# Altium schematic sheet STB_ControlBoard.SchDoc
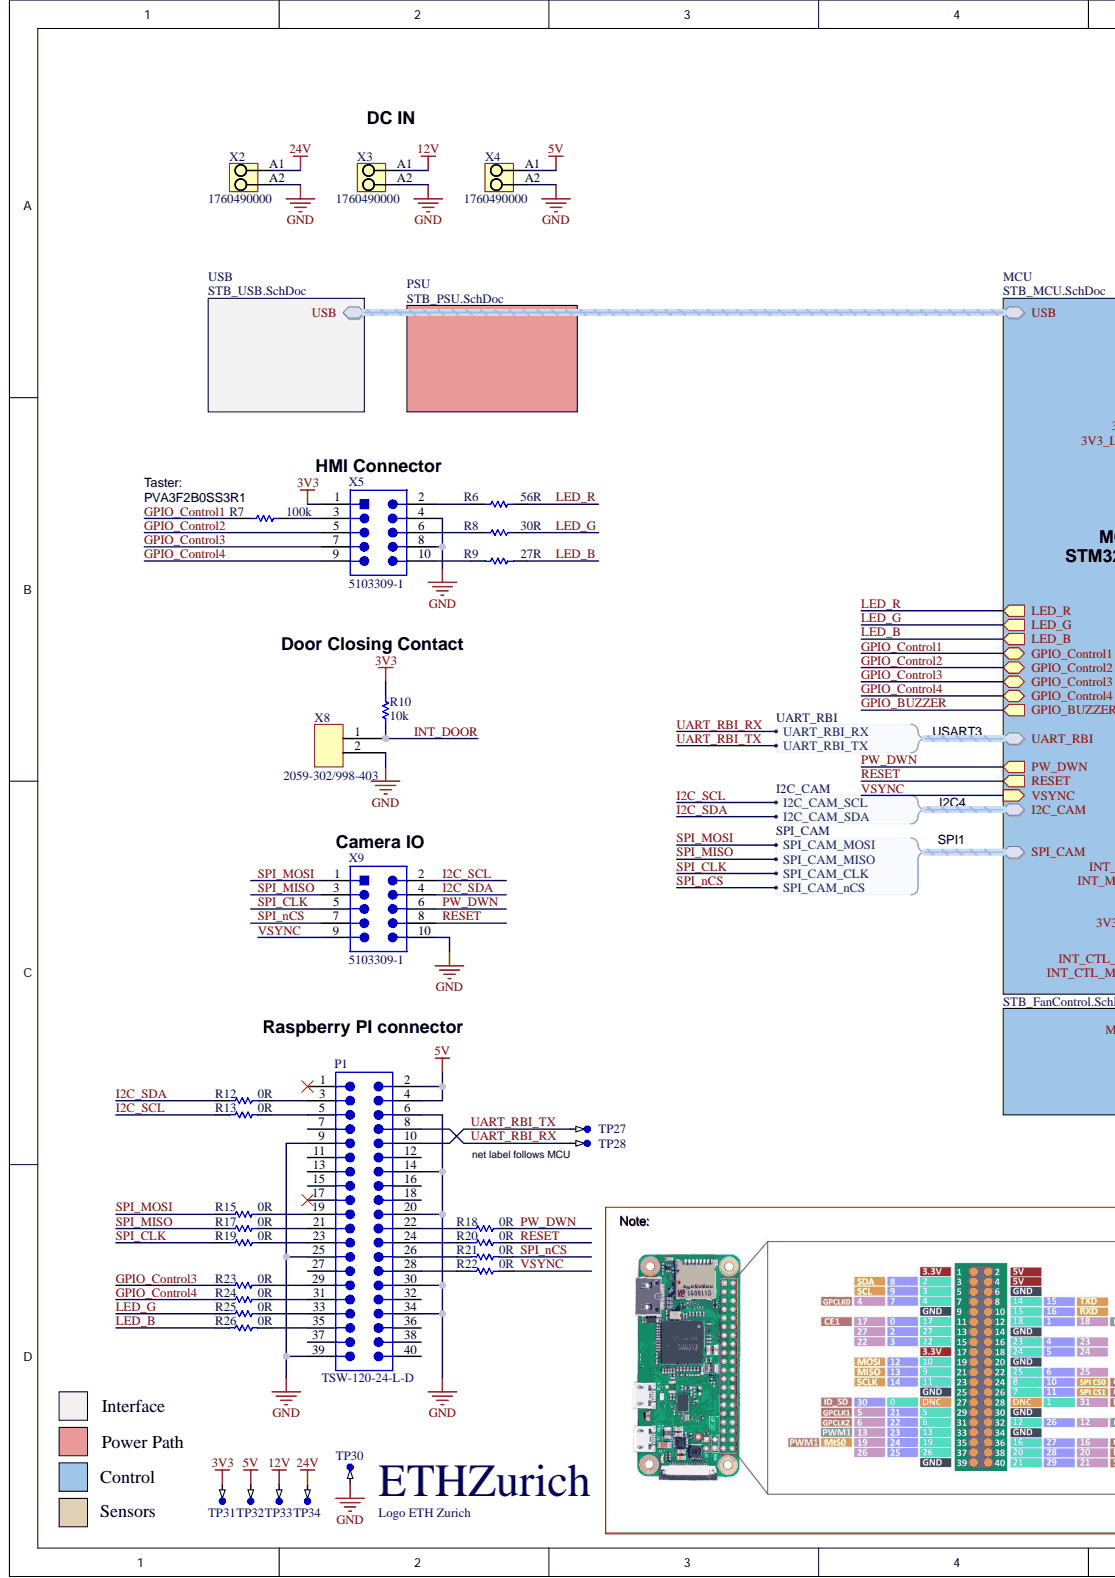
[diagram p0: STB_ControlBoard.SchDoc, left]
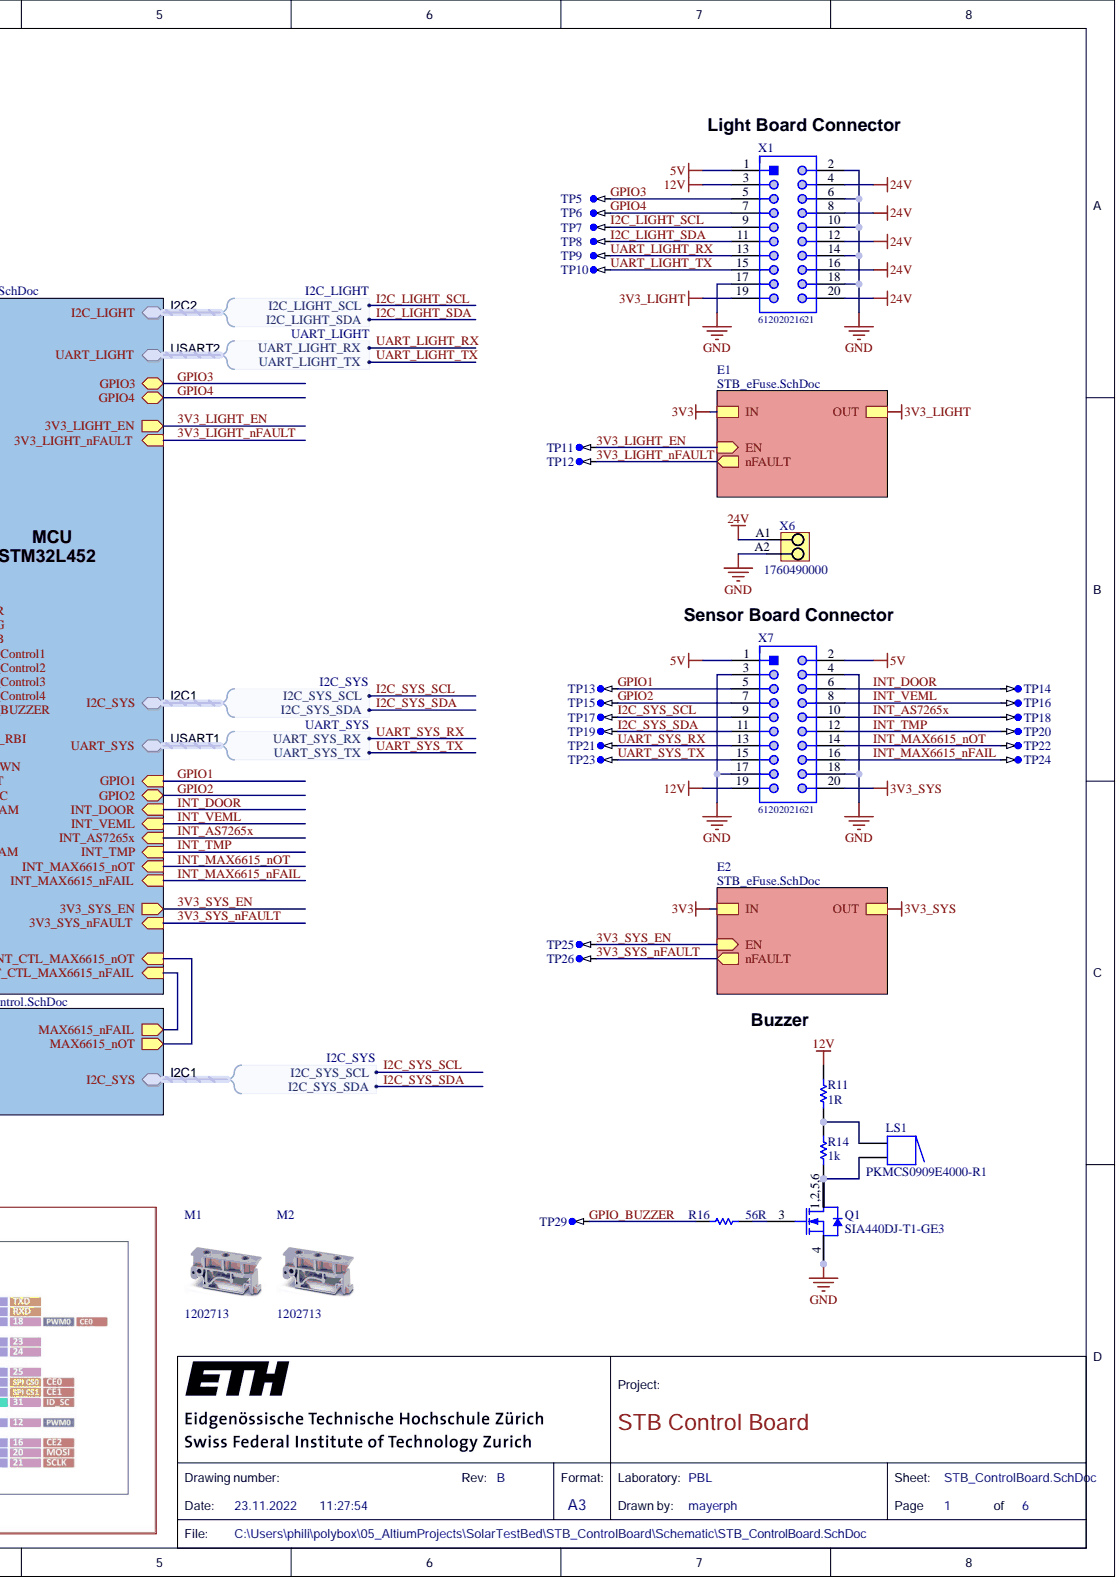
[diagram p1: STB_ControlBoard.SchDoc, right]

## Parts
A1  Logo ETH Zurich  [eth-zurich_3mm]  (no BOM)
LS1  PKMCS0909E4000-R1  [MURA- PKMCS0909E4000-2_V]
M1  1202713  [1202713]
M2  1202713  [1202713]
P1  TSW-120-24-L-D  [SMTC-TSW-120-24-X-D]
Q1  SIA440DJ-T1-GE3  [SC70-6L_65P210X80_HS-7L]
R6  56R  [RESC1609X50X30LL10T20]
R7  100k  [RESC1005X40X25LL05T10]
R8  30R  [RESC1609X50X30LL10T20]
R9  27R  [RESC1608X55X30LL15T15]
R10  10k  [RESC1005X40X25LL05T05]
R11  1R  [RESC3225X70X50NL20T20]
R12  0R  [RESC1005X40X25LL05T10]
R13  0R  [RESC1005X40X25LL05T10]
R14  1k  [RESC3216X70X50LL05T20]
R15  0R  [RESC1005X40X25LL05T10]
R16  56R  [RESC1609X50X30LL10T20]
R17  0R  [RESC1005X40X25LL05T10]
R18  0R  [RESC1005X40X25LL05T10]
R19  0R  [RESC1005X40X25LL05T10]
R20  0R  [RESC1005X40X25LL05T10]
R21  0R  [RESC1005X40X25LL05T10]
R22  0R  [RESC1005X40X25LL05T10]
R23  0R  [RESC1005X40X25LL05T10]
R24  0R  [RESC1005X40X25LL05T10]
R25  0R  [RESC1005X40X25LL05T10]
R26  0R  [RESC1005X40X25LL05T10]
TP5  TP_1mm  [TP-SM-C-100]  (value not drawn)  (no BOM)
TP6  TP_1mm  [TP-SM-C-100]  (value not drawn)  (no BOM)
TP7  TP_1mm  [TP-SM-C-100]  (value not drawn)  (no BOM)
TP8  TP_1mm  [TP-SM-C-100]  (value not drawn)  (no BOM)
TP9  TP_1mm  [TP-SM-C-100]  (value not drawn)  (no BOM)
TP10  TP_1mm  [TP-SM-C-100]  (value not drawn)  (no BOM)
TP11  TP_1mm  [TP-SM-C-100]  (value not drawn)  (no BOM)
TP12  TP_1mm  [TP-SM-C-100]  (value not drawn)  (no BOM)
TP13  TP_1mm  [TP-SM-C-100]  (value not drawn)  (no BOM)
TP14  TP_1mm  [TP-SM-C-100]  (value not drawn)  (no BOM)
TP15  TP_1mm  [TP-SM-C-100]  (value not drawn)  (no BOM)
TP16  TP_1mm  [TP-SM-C-100]  (value not drawn)  (no BOM)
TP17  TP_1mm  [TP-SM-C-100]  (value not drawn)  (no BOM)
TP18  TP_1mm  [TP-SM-C-100]  (value not drawn)  (no BOM)
TP19  TP_1mm  [TP-SM-C-100]  (value not drawn)  (no BOM)
TP20  TP_1mm  [TP-SM-C-100]  (value not drawn)  (no BOM)
TP21  TP_1mm  [TP-SM-C-100]  (value not drawn)  (no BOM)
TP22  TP_1mm  [TP-SM-C-100]  (value not drawn)  (no BOM)
TP23  TP_1mm  [TP-SM-C-100]  (value not drawn)  (no BOM)
TP24  TP_1mm  [TP-SM-C-100]  (value not drawn)  (no BOM)
TP25  TP_1mm  [TP-SM-C-100]  (value not drawn)  (no BOM)
TP26  TP_1mm  [TP-SM-C-100]  (value not drawn)  (no BOM)
TP27  TP_1mm  [TP-SM-C-100]  (value not drawn)  (no BOM)
TP28  TP_1mm  [TP-SM-C-100]  (value not drawn)  (no BOM)
TP29  TP_1mm  [TP-SM-C-100]  (value not drawn)  (no BOM)
TP30  TP_1mm  [TP-SM-C-100]  (value not drawn)  (no BOM)
TP31  TP_1mm  [TP-SM-C-100]  (value not drawn)  (no BOM)
TP32  TP_1mm  [TP-SM-C-100]  (value not drawn)  (no BOM)
TP33  TP_1mm  [TP-SM-C-100]  (value not drawn)  (no BOM)
TP34  TP_1mm  [TP-SM-C-100]  (value not drawn)  (no BOM)
X1  61202021621  [61202021621]
X2  1760490000  [PM_5_08_90_02]
X3  1760490000  [PM_5_08_90_02]
X4  1760490000  [PM_5_08_90_02]
X5  5103309-1  [TECO-5103309-1_V]
X6  1760490000  [PM_5_08_90_02]
X7  61202021621  [61202021621]
X8  2059-302/998-403  [2059-302/998-403]
X9  5103309-1  [TECO-5103309-1_V]

## Nets
3V3: R10.2 TP31.TP X5.1
3V3_LIGHT: X1.19
3V3_SYS: X7.20
5V: P1.2 P1.4 TP32.TP X1.1 X4.A1 X7.1 X7.2
12V: R11.2 TP33.TP X1.3 X3.A1 X7.19
24V: TP34.TP X1.4 X1.8 X1.12 X1.16 X1.20 X2.A1 X6.A1
GND: P1.6 P1.9 P1.14 P1.20 P1.25 P1.30 P1.34 P1.39 Q1.4 Q1.S TP30.TP X1.2 X1.6 X1.10 X1.14 X1.17 X1.18 X2.A2 X3.A2 X4.A2 X5.4 X5.8 X6.A2 X7.3 X7.4 X7.17 X7.18 X8.2 X9.10
3V3_LIGHT_EN: TP11.TP
3V3_LIGHT_nFAULT: TP12.TP
3V3_SYS_EN: TP25.TP
3V3_SYS_nFAULT: TP26.TP
GPIO1: TP13.TP X7.5
GPIO2: TP15.TP X7.7
GPIO3: TP5.TP X1.5
GPIO4: TP6.TP X1.7
GPIO_BUZZER: R16.2 TP29.TP
GPIO_Control1: R7.2
GPIO_Control2: X5.5
GPIO_Control3: R23.1 X5.7
GPIO_Control4: R24.1 X5.9
I2C_LIGHT_SCL: TP7.TP X1.9
I2C_LIGHT_SDA: TP8.TP X1.11
I2C_SCL: R13.1 X9.2
I2C_SDA: R12.1 X9.4
I2C_SYS_SCL: TP17.TP X7.9
I2C_SYS_SDA: TP19.TP X7.11
INT_AS7265x: TP18.TP X7.10
INT_DOOR: R10.1 TP14.TP X7.6 X8.1
INT_MAX6615_nFAIL: TP24.TP X7.16
INT_MAX6615_nOT: TP22.TP X7.14
INT_TMP: TP20.TP X7.12
INT_VEML: TP16.TP X7.8
LED_B: R9.1 R26.1
LED_G: R8.1 R25.1
LED_R: R6.1
PW_DWN: R18.2 X9.6
RESET: R20.2 X9.8
SPI_CLK: R19.1 X9.5
SPI_MISO: R17.1 X9.3
SPI_MOSI: R15.1 X9.1
SPI_nCS: R21.2 X9.7
UART_LIGHT_RX: TP9.TP X1.13
UART_LIGHT_TX: TP10.TP X1.15
UART_RBI_RX: P1.8 TP28.TP
UART_RBI_TX: P1.10 TP27.TP
UART_SYS_RX: TP21.TP X7.13
UART_SYS_TX: TP23.TP X7.15
VSYNC: R22.2 X9.9
NetLS1_1: LS1.1 R11.1 R14.2
NetLS1_2: LS1.2 Q1.1 Q1.2 Q1.5 Q1.6 Q1.TP R14.1
NetP1_1: P1.1
NetP1_3: P1.3 R12.2
NetP1_5: P1.5 R13.2
NetP1_7: P1.7
NetP1_11: P1.11
NetP1_12: P1.12
NetP1_13: P1.13
NetP1_15: P1.15
NetP1_16: P1.16
NetP1_17: P1.17
NetP1_18: P1.18
NetP1_19: P1.19 R15.2
NetP1_21: P1.21 R17.2
NetP1_22: P1.22 R18.1
NetP1_23: P1.23 R19.2
NetP1_24: P1.24 R20.1
NetP1_26: P1.26 R21.1
NetP1_27: P1.27
NetP1_28: P1.28 R22.1
NetP1_29: P1.29 R23.2
NetP1_31: P1.31 R24.2
NetP1_32: P1.32
NetP1_33: P1.33 R25.2
NetP1_35: P1.35 R26.2
NetP1_36: P1.36
NetP1_37: P1.37
NetP1_38: P1.38
NetP1_40: P1.40
NetQ1_3: Q1.3 R16.1
NetR6_2: R6.2 X5.2
NetR7_1: R7.1 X5.3
NetR8_2: R8.2 X5.6
NetR9_2: R9.2 X5.10
INT_CTL_MAX6615_nFAIL:
INT_CTL_MAX6615_nOT:
USB.USB_N:
USB.USB_P:

## Library data of the parts
A1 = CMP-00038-1: Library Name: ETHZ Vault
LS1 = CMP-2000-05252-1: Audio Indicators & Alerts Piezo Buzzer, 12.5 V, -40 to 85 degC, 2-Pin SMD, RoHS, Tape and Reel; Manufacturer: Murata; Brand: MurataElectronics; Frequency: 4kHz; Sound Pressure Level: 65dBA; Tone: Beep; Voltage Rating: 12.5VDC; Application: AlertBeep; Component Kind: Standard; Datasheet URL: https://datasheet.ciiva.com/24244/pkmcs0909e4000-r1-304946-24244386.pdf; Datasheet Version: Feb-13; Dimensions: 9mmLx9mmWx1.9mmH; Factory Pack Quantity: 2000; Height: 1.9mm; Height - in: 0.075in; Height - mm: 1.9mm; Length: 9mm; Length - in: 0.354in; Length - mm: 9mm; Library Name: Altium Content Vault; Manufacturer Part Number: PKMCS0909E4000-R1; Manufacturer URL: http://www.murata.com/; Mounting: SMD/SMT; Packaging: Reel; Product: Speakers; Product Category: AudioIndicators&Alerts; RoHS: True; Series: PK; Termination Style: GullwingLead; Tradename: PIEZORINGER; Type: Piezoelectric; Width: 9mm; Width - in: 0.354in; Width - mm: 9mm
M1 M2 = 1202713: EMI/EMD/EMC Protections; Manufacturer: Phoenix Contact; Category: Connectors; Component Kind: Standard; ComponentLink1Description: https://datasheet.ciiva.com:443/pdfs/VipMasterIC/IC/PCON/PCON-S-A0000016077/PCON-S-A0000017096-1.pdf?src-supplier=IHS+Markit; ComponentLink1URL: https://datasheet.ciiva.com:443/pdfs/VipMasterIC/IC/PCON/PCON-S-A0000016077/PCON-S-A0000017096-1.pdf?src-supplier=IHS+Markit; ComponentLink2Description: https://datasheet.ciiva.com/6712/1202713-6712528.pdf?src-supplier=Digi-Key; ComponentLink2URL: https://datasheet.ciiva.com/6712/1202713-6712528.pdf?src-supplier=Digi-Key; ComponentLink3Description: https://datasheet.ciiva.com/15656/1202713-15656099.pdf?src-supplier=Onlinecomponents.com; ComponentLink3URL: https://datasheet.ciiva.com/15656/1202713-15656099.pdf?src-supplier=Onlinecomponents.com; ComponentLink4Description: https://datasheet.ciiva.com/29271/715928-da-01-de-schienenadapter-1202713-10-st-29271415.pdf?src-supplier=Conrad; ComponentLink4URL: https://datasheet.ciiva.com/29271/715928-da-01-de-schienenadapter-1202713-10-st-29271415.pdf?src-supplier=Conrad; ComponentLink5Description: https://datasheet.ciiva.com/14616/70171402-14616683.pdf?src-supplier=Allied+Electronics; ComponentLink5URL: https://datasheet.ciiva.com/14616/70171402-14616683.pdf?src-supplier=Allied+Electronics; Manufacturer Part Number: 1202713; Pricing 1: 1=1.92000, 10=1.47000, 50=1.35240, 100=1.24950, 250=1.19072, 500=1.11720, 1000=1.05876, 2500=1.03951, 5000=1.02411 (USD); RoHS: Yes; Stock 1: 1956; Supplier 1: Digi-Key; Supplier Part Number 1: 277-2289-ND; Library Name: STB_Schlib.SchLib
P1 = CMP-1024-00454-1: 0.025" SQ Post Header, Through-hole, Vertical, -55 to 125 degC, 2.54 mm Pitch, 40-Pin, Male, RoHS; Manufacturer: Samtec; Pitch: 2.54 mm; Component Kind: Standard; ComponentLink1Description: Manufacturer URL; ComponentLink1URL: http://www.samtec.com; ComponentLink2Description: Datasheet; ComponentLink2URL: http://www.samtec.com/documents/webfiles/pdf/TSW_TH.PDF; ComponentLink3Description: Package Specification; ComponentLink3URL: http://www.samtec.com/documents/webfiles/cpdf/TSW-XXX-XX-XXX-X-XX-XXX-MKT.pdf; DatasheetVersion: F-214; Library Name: Altium Content Vault; Manufacturer Part Number: TSW-120-24-L-D; Mounting Technology: Through-hole; Orientation: Vertical; PackageDescription: .025[0.64] SQ. Post Terminal Strip Assembly; PackageReference: TSW-120-24-X-D; PackageVersion: DM, 8/1988; RoHS: True; Temperature Range: -55 to 125 degC
Q1 = SIA440DJ-T1-GE3: VISHAY   SIA440DJ-T1-GE3   MOSFET Transistor, N Channel, 12 A, 40 V, 0.021 ohm, 10 V; Manufacturer: Vishay Siliconix; Continuous Drain Current (ID): 12A; Drain to Source Breakdown Voltage: 40V; Drain to Source Resistance: 26mR; Element Configuration: Single; Fall Time: 5ns; Gate to Source Voltage (Vgs): 12V; Input Capacitance: 700pF; Max Power Dissipation: 19W; Number of Channels: 1; Rise Time: 32ns; Turn-Off Delay Time: 23ns; Turn-On Delay Time: 12ns; Category: MOSFETs; Component Kind: Standard; ComponentLink1Description: https://datasheet.ciiva.com/29746/sia440dj-29746688.pdf?src-supplier=Digi-Key; ComponentLink1URL: https://datasheet.ciiva.com/29746/sia440dj-29746688.pdf?src-supplier=Digi-Key; ComponentLink2Description: https://datasheet.ciiva.com/24094/sia440dj-267638-24094753.pdf?src-supplier=Mouser; ComponentLink2URL: https://datasheet.ciiva.com/24094/sia440dj-267638-24094753.pdf?src-supplier=Mouser; Drain to Source Voltage (Vdss): 40V; Height: 0.75mm; Length: 2.05mm; Manufacturer Part Number: SIA440DJ-T1-GE3; Max Operating Temperature: 150°C; Min Operating Temperature: -55°C; Mount: Surface Mount; Number of Pins: 6; Package Quantity: 3000; Packaging: Tape and Reel; Pricing 1: 1=0.46000, 10=0.39000, 25=0.36400, 100=0.27040, 250=0.25688, 500=0.21112, 1000=0.17160 (USD); Radiation Hardening: No; Rds On Max: 26mR; REACH SVHC: Unknown; RoHS: Yes; Stock 1: 7570; Supplier 1: Digi-Key; Supplier Part Number 1: SIA440DJ-T1-GE3CT-ND; Width: 2.05mm; Library Name: STB_Schlib.SchLib
R6 R16 = CMP-2000-03471-1: Manufacturer: Vishay Dale; Manufacturer Part Number: CRCW060356R0FKEA; Package Height: 0.45mm; Package Length: 1.55mm; Package Width: 0.85mm; Rated Power Dissipation (P): 100mW; Rated Temperature: 70°C; Reference Standard: AEC-Q200; Temperature Coefficient: 100ppm/°C; Tolerance: 1%; Value: 56 Ohm; Working Voltage: 75V; Category: Chip SMD Resistors; Component Kind: Standard; ComponentLink1Description: https://datasheet.ciiva.com:443/pdfs/VipMasterIC/IC/VISH/VISH-S-A0007266705/VISH-S-A0007266705-1.pdf?src-supplier=IHS+Markit; ComponentLink1URL: https://datasheet.ciiva.com:443/pdfs/VipMasterIC/IC/VISH/VISH-S-A0007266705/VISH-S-A0007266705-1.pdf?src-supplier=IHS+Markit; ComponentLink2Description: https://datasheet.ciiva.com/11810/0900766b80d98afc-11810033.pdf?src-supplier=RSComponents; ComponentLink2URL: https://datasheet.ciiva.com/11810/0900766b80d98afc-11810033.pdf?src-supplier=RSComponents; ComponentLink3Description: https://datasheet.ciiva.com/2465/dcrcwe3-2465643.pdf?src-supplier=Digi-Key; ComponentLink3URL: https://datasheet.ciiva.com/2465/dcrcwe3-2465643.pdf?src-supplier=Digi-Key; ComponentLink4Description: https://datasheet.ciiva.com/3257/dcrcwe3-3257739.pdf?src-supplier=Avnet; ComponentLink4URL: https://datasheet.ciiva.com/3257/dcrcwe3-3257739.pdf?src-supplier=Avnet; ComponentLink5Description: https://datasheet.ciiva.com/22704/1697337-22704443.pdf?src-supplier=Element14; ComponentLink5URL: https://datasheet.ciiva.com/22704/1697337-22704443.pdf?src-supplier=Element14; ComponentLink6Description: https://datasheet.ciiva.com/3257/dcrcwe3-3257740.pdf?src-supplier=Avnet; ComponentLink6URL: https://datasheet.ciiva.com/3257/dcrcwe3-3257740.pdf?src-supplier=Avnet; ComponentLink7Description: https://datasheet.ciiva.com/16785/70201119-16785354.pdf?src-supplier=Allied+Electronics; ComponentLink7URL: https://datasheet.ciiva.com/16785/70201119-16785354.pdf?src-supplier=Allied+Electronics; ComponentLink8Description: https://datasheet.ciiva.com/2465/dcrcwe3-2465644.pdf?src-supplier=Digi-Key; ComponentLink8URL: https://datasheet.ciiva.com/2465/dcrcwe3-2465644.pdf?src-supplier=Digi-Key; ComponentLink9Description: https://datasheet.ciiva.com/7672/1697337-7672917.pdf?src-supplier=Element14; ComponentLink9URL: https://datasheet.ciiva.com/7672/1697337-7672917.pdf?src-supplier=Element14; ComponentLink10Description: https://datasheet.ciiva.com/2465/dcrcwe3-2465642.pdf?src-supplier=Digi-Key; ComponentLink10URL: https://datasheet.ciiva.com/2465/dcrcwe3-2465642.pdf?src-supplier=Digi-Key; ComponentLink11Description: https://datasheet.ciiva.com/16507/dcrcw-16507994.pdf?src-supplier=Future+Electronics; ComponentLink11URL: https://datasheet.ciiva.com/16507/dcrcw-16507994.pdf?src-supplier=Future+Electronics; Construction: Chip; JESD-609 Code: e3; Library Name: Altium Content Vault; Max Operating Temperature: 155°C; Min Operating Temperature: -55°C; Mount: Surface Mount; Number of Pins: 2; Package Shape: Rectangular; Package Style: SMTMeter; Packaging: Tape and Reel; Part Number: CRCW060356R0FKEA; Pricing 1: 1=0.10000, 10=0.03700, 100=0.01490, 1000=0.00670, 2500=0.00582 (USD); REACH SVHC: Yes; Resistor Type: FIXED RESISTOR; RoHS: Yes; RoHS Compliant: Yes; Size Code: 0603; Stock 1: 216; Supplier 1: Digi-Key; Supplier Part Number 1: 541-56.0HCT-ND; Technology: METAL GLAZE/THICK FILM; Terminal Finish: Nickel; Termination: Wraparound
R7 = CMP-2002-07343-1: Manufacturer: Yageo / Phycomp; Manufacturer Part Number: RC0402FR-07100KL; Additional Feature: LASER TRIMMABLE; Package Height: 0.35mm; Package Length: 1mm; Package Width: 0.5mm; Rated Power Dissipation (P): 62.5mW; Rated Temperature: 70°C; Temperature Coefficient: 100ppm/°C; Tolerance: 1%; Value: 100 kOhm; Working Voltage: 50V; Category: Chip SMD Resistors; Component Kind: Standard; ComponentLink1Description: https://datasheet.ciiva.com:443/pdfs/VipMasterIC/IC/YAGO/YAGO-S-A0008145938/YAGO-S-A0008145938-1.pdf?src-supplier=IHS+Markit; ComponentLink1URL: https://datasheet.ciiva.com:443/pdfs/VipMasterIC/IC/YAGO/YAGO-S-A0008145938/YAGO-S-A0008145938-1.pdf?src-supplier=IHS+Markit; Construction: Chip; JESD-609 Code: e3; Library Name: Altium Content Vault; Max Operating Temperature: 155°C; Min Operating Temperature: -55°C; Mount: Surface Mount; Number of Pins: 2; Package Shape: Rectangular; Package Style: SMTMeter; Packaging: Tape and Reel; Part Number: RC0402FR-07100KL; Pricing 1: 1=0.10000, 10=0.01500, 100=0.00600, 1000=0.00267, 2500=0.00232, 5000=0.00192 (USD); REACH SVHC: Yes; Resistor Type: FIXED RESISTOR; RoHS: Yes; RoHS Compliant: Yes; Size Code: 0402; Stock 1: 43269933; Supplier 1: Digi-Key; Supplier Part Number 1: 311-100KLRDKR-ND; Technology: METAL GLAZE/THICK FILM; Terminal Finish: Nickel; Termination: Wraparound
R8 = CMP-2000-03228-1: Manufacturer: Vishay Dale; Manufacturer Part Number: CRCW060330R0FKEA; Package Height: 0.45mm; Package Length: 1.55mm; Package Width: 0.85mm; Rated Power Dissipation (P): 100mW; Rated Temperature: 70°C; Reference Standard: AEC-Q200; Temperature Coefficient: 100ppm/°C; Tolerance: 1%; Value: 30 Ohm; Working Voltage: 75V; Category: Chip SMD Resistors; Component Kind: Standard; ComponentLink1Description: https://datasheet.ciiva.com:443/pdfs/VipMasterIC/IC/VISH/VISH-S-A0007266705/VISH-S-A0007266705-1.pdf?src-supplier=IHS+Markit; ComponentLink1URL: https://datasheet.ciiva.com:443/pdfs/VipMasterIC/IC/VISH/VISH-S-A0007266705/VISH-S-A0007266705-1.pdf?src-supplier=IHS+Markit; ComponentLink2Description: https://datasheet.ciiva.com/11812/0900766b80d98afc-11812614.pdf?src-supplier=RSComponents; ComponentLink2URL: https://datasheet.ciiva.com/11812/0900766b80d98afc-11812614.pdf?src-supplier=RSComponents; ComponentLink3Description: https://datasheet.ciiva.com/2466/dcrcwe3-2466161.pdf?src-supplier=Digi-Key; ComponentLink3URL: https://datasheet.ciiva.com/2466/dcrcwe3-2466161.pdf?src-supplier=Digi-Key; ComponentLink4Description: https://datasheet.ciiva.com/2466/dcrcwe3-2466162.pdf?src-supplier=Digi-Key; ComponentLink4URL: https://datasheet.ciiva.com/2466/dcrcwe3-2466162.pdf?src-supplier=Digi-Key; ComponentLink5Description: https://datasheet.ciiva.com/2466/dcrcwe3-2466163.pdf?src-supplier=Digi-Key; ComponentLink5URL: https://datasheet.ciiva.com/2466/dcrcwe3-2466163.pdf?src-supplier=Digi-Key; ComponentLink6Description: https://datasheet.ciiva.com/7732/1697337-7732041.pdf?src-supplier=Element14; ComponentLink6URL: https://datasheet.ciiva.com/7732/1697337-7732041.pdf?src-supplier=Element14; ComponentLink7Description: https://datasheet.ciiva.com/8338/dcrcwe3-8338278.pdf?src-supplier=Avnet; ComponentLink7URL: https://datasheet.ciiva.com/8338/dcrcwe3-8338278.pdf?src-supplier=Avnet; ComponentLink8Description: https://datasheet.ciiva.com/16767/70201119-16767414.pdf?src-supplier=Allied+Electronics; ComponentLink8URL: https://datasheet.ciiva.com/16767/70201119-16767414.pdf?src-supplier=Allied+Electronics; ComponentLink9Description: https://datasheet.ciiva.com/5546/dcrcwe3-109170-5546968.pdf?src-supplier=Mouser; ComponentLink9URL: https://datasheet.ciiva.com/5546/dcrcwe3-109170-5546968.pdf?src-supplier=Mouser; ComponentLink10Description: https://datasheet.ciiva.com/7732/1697337-7732040.pdf?src-supplier=Element14; ComponentLink10URL: https://datasheet.ciiva.com/7732/1697337-7732040.pdf?src-supplier=Element14; ComponentLink11Description: https://datasheet.ciiva.com/22708/1697337-22708344.pdf?src-supplier=Element14; ComponentLink11URL: https://datasheet.ciiva.com/22708/1697337-22708344.pdf?src-supplier=Element14; Construction: Chip; JESD-609 Code: e3; Library Name: Altium Content Vault; Max Operating Temperature: 155°C; Min Operating Temperature: -55°C; Mount: Surface Mount; Number of Pins: 2; Package Shape: Rectangular; Package Style: SMTMeter; Packaging: Tape and Reel; Part Number: CRCW060330R0FKEA; Pricing 1: 1=0.10000, 10=0.03700, 100=0.01490, 1000=0.00670, 2500=0.00582 (USD); REACH SVHC: Yes; Resistor Type: FIXED RESISTOR; RoHS: Yes; RoHS Compliant: Yes; Size Code: 0603; Stock 1: 1285; Supplier 1: Digi-Key; Supplier Part Number 1: 541-30.0HDKR-ND; Technology: METAL GLAZE/THICK FILM; Terminal Finish: Nickel; Termination: Wraparound
R9 = CMP-2000-00757-1: Manufacturer: Panasonic; Manufacturer Part Number: ERJ-3EKF27R0V; Part Number: ERJ-3GEYJ270V; Package Height: 0.45mm; Package Length: 1.6mm; Package Width: 0.8mm; Rated Power Dissipation (P): 100mW; Rated Temperature: 70°C; Reference Standard: AEC-Q200; Temperature Coefficient: 100ppm/°C; Tolerance: 1%; Value: 27 Ohm; Working Voltage: 75V; Category: Chip SMD Resistors; Component Kind: Standard; ComponentLink1Description: https://datasheet.ciiva.com:443/pdfs/VipMasterIC/IC/PANA/PANA-S-A0009889626/PANA-S-A0009889626-1.pdf?src-supplier=IHS+Markit; ComponentLink1URL: https://datasheet.ciiva.com:443/pdfs/VipMasterIC/IC/PANA/PANA-S-A0009889626/PANA-S-A0009889626-1.pdf?src-supplier=IHS+Markit; ComponentLink2Description: https://datasheet.ciiva.com/46381/1678782-46381559.pdf?src-supplier=Element14; ComponentLink2URL: https://datasheet.ciiva.com/46381/1678782-46381559.pdf?src-supplier=Element14; ComponentLink3Description: https://datasheet.ciiva.com/22681/1678782-22681182.pdf?src-supplier=Element14; ComponentLink3URL: https://datasheet.ciiva.com/22681/1678782-22681182.pdf?src-supplier=Element14; ComponentLink4Description: https://datasheet.ciiva.com/28568/1678782-28568703.pdf?src-supplier=Element14; ComponentLink4URL: https://datasheet.ciiva.com/28568/1678782-28568703.pdf?src-supplier=Element14; ComponentLink5Description: https://datasheet.ciiva.com/46381/1678782-46381558.pdf?src-supplier=Element14; ComponentLink5URL: https://datasheet.ciiva.com/46381/1678782-46381558.pdf?src-supplier=Element14; ComponentLink6Description: https://datasheet.ciiva.com/24069/aoa0000ce2-24069132.pdf?src-supplier=Mouser; ComponentLink6URL: https://datasheet.ciiva.com/24069/aoa0000ce2-24069132.pdf?src-supplier=Mouser; ComponentLink7Description: https://datasheet.ciiva.com/16776/70260748-16776005.pdf?src-supplier=Allied+Electronics; ComponentLink7URL: https://datasheet.ciiva.com/16776/70260748-16776005.pdf?src-supplier=Allied+Electronics; ComponentLink8Description: https://datasheet.ciiva.com/16779/70260748-16779605.pdf?src-supplier=Allied+Electronics; ComponentLink8URL: https://datasheet.ciiva.com/16779/70260748-16779605.pdf?src-supplier=Allied+Electronics; ComponentLink9Description: https://datasheet.ciiva.com/9116/aoa0000ce2-9116957.pdf?src-supplier=Avnet; ComponentLink9URL: https://datasheet.ciiva.com/9116/aoa0000ce2-9116957.pdf?src-supplier=Avnet; ComponentLink10Description: https://datasheet.ciiva.com/9191/aoa0000ce2-9191131.pdf?src-supplier=Avnet; ComponentLink10URL: https://datasheet.ciiva.com/9191/aoa0000ce2-9191131.pdf?src-supplier=Avnet; ComponentLink11Description: https://datasheet.ciiva.com/30124/aoa0000ce2-30124664.pdf?src-supplier=Onlinecomponents.com; ComponentLink11URL: https://datasheet.ciiva.com/30124/aoa0000ce2-30124664.pdf?src-supplier=Onlinecomponents.com; Construction: Rectangular; JESD-609 Code: e3; Library Name: Altium Content Vault; Max Operating Temperature: 155°C; Min Operating Temperature: -55°C; Mount: Surface Mount; Number of Pins: 2; Package Shape: Rectangular; Package Style: SMTMeter; Packaging: Tape and Reel; Pricing 1: 1000=0.01300 (CHF); REACH SVHC: Yes; Resistor Type: FIXED RESISTOR; RoHS: Yes; RoHS Compliant: Yes; Size Code: 0603; Stock 1: 109978; Supplier 1: RSComponents; Supplier Part Number 1: 1763578; Technology: METAL GLAZE/THICK FILM; Terminal Finish: Nickel; Termination: Wraparound
R10 = CMP-2000-07588-1: Chip Resistor, 10 KOhm, +/- 1%, 0.1 W, -55 to 155 degC, 0402 (1005 Metric), RoHS, Tape and Reel; Manufacturer: Panasonic; Manufacturer Part Number: ERJ-2RKF1002X; Case\/Package: 0402; Max Power Dissipation: 100mW; Package Height: 0.35mm; Package Length: 1mm; Package Width: 0.5mm; Rated Temperature: 70°C; Reference Standard: AEC-Q200; Temperature Coefficient: 100ppm\/degreesC; Value: 10 kOhm; Voltage Rating: 50V; Case Code (Imperial): 0402; Case Code (Metric): 1005; Category: Chip SMD Resistors; Component Kind: Standard; ComponentLink1Description: https://datasheet.ciiva.com:443/pdfs/VipMasterIC/IC/PANA/PANA-S-A0009889626/PANA-S-A0009889626-1.pdf?src-supplier=IHS+Markit; ComponentLink1URL: https://datasheet.ciiva.com:443/pdfs/VipMasterIC/IC/PANA/PANA-S-A0009889626/PANA-S-A0009889626-1.pdf?src-supplier=IHS+Markit; ComponentLink2Description: https://datasheet.ciiva.com/11821/0900766b80f80784-11821171.pdf?src-supplier=RSComponents; ComponentLink2URL: https://datasheet.ciiva.com/11821/0900766b80f80784-11821171.pdf?src-supplier=RSComponents; ComponentLink3Description: https://datasheet.ciiva.com/27055/1987020-27055643.pdf?src-supplier=Jameco; ComponentLink3URL: https://datasheet.ciiva.com/27055/1987020-27055643.pdf?src-supplier=Jameco; ComponentLink4Description: https://datasheet.ciiva.com/43467/1678782-43467402.pdf?src-supplier=Farnell; ComponentLink4URL: https://datasheet.ciiva.com/43467/1678782-43467402.pdf?src-supplier=Farnell; ComponentLink5Description: https://datasheet.ciiva.com/28514/1678782-28514185.pdf?src-supplier=Element14; ComponentLink5URL: https://datasheet.ciiva.com/28514/1678782-28514185.pdf?src-supplier=Element14; ComponentLink6Description: https://datasheet.ciiva.com/24023/aoa0000ce2-24023017.pdf?src-supplier=Mouser; ComponentLink6URL: https://datasheet.ciiva.com/24023/aoa0000ce2-24023017.pdf?src-supplier=Mouser; ComponentLink7Description: https://datasheet.ciiva.com/16782/70260748-16782590.pdf?src-supplier=Allied+Electronics; ComponentLink7URL: https://datasheet.ciiva.com/16782/70260748-16782590.pdf?src-supplier=Allied+Electronics; ComponentLink8Description: https://datasheet.ciiva.com/16786/70260748-16786734.pdf?src-supplier=Allied+Electronics; ComponentLink8URL: https://datasheet.ciiva.com/16786/70260748-16786734.pdf?src-supplier=Allied+Electronics; ComponentLink9Description: https://datasheet.ciiva.com/9194/aoa0000ce2-9194142.pdf?src-supplier=Avnet; ComponentLink9URL: https://datasheet.ciiva.com/9194/aoa0000ce2-9194142.pdf?src-supplier=Avnet; ComponentLink10Description: https://datasheet.ciiva.com/16199/aoa0000ce2-16199478.pdf?src-supplier=Future+Electronics; ComponentLink10URL: https://datasheet.ciiva.com/16199/aoa0000ce2-16199478.pdf?src-supplier=Future+Electronics; ComponentLink11Description: https://datasheet.ciiva.com/48531/aoa0000ce2-48531069.pdf?src-supplier=Avnet; ComponentLink11URL: https://datasheet.ciiva.com/48531/aoa0000ce2-48531069.pdf?src-supplier=Avnet; ComponentLink12Description: https://datasheet.ciiva.com/30201/aoa0000ce2-30201022.pdf?src-supplier=Onlinecomponents.com; ComponentLink12URL: https://datasheet.ciiva.com/30201/aoa0000ce2-30201022.pdf?src-supplier=Onlinecomponents.com; Composition: ThickFilm; Construction: Rectangular; Contact Plating: Tin; Datasheet URL: https://industrial.panasonic.com/cdbs/www-data/pdf/RDA0000/AOA0000C304.pdf; Datasheet Version: 02/2017; Depth: 0.5mm; Height: 0.35mm; JESD-609 Code: e3; Lead Free: LeadFree; Length: 1mm; Library Name: Altium Content Vault; Manufacturer URL: http://www.panasonic.com/; Max Operating Temperature: 155degC; Military Standard: Not; Min Operating Temperature: -55degC; Mount: SurfaceMount; Mounting Technology: SurfaceMount; Number of Pins: 2; Number of Terminations: 2; Package Description: 2-Pin Surface Mount Device, Body 1 x 0.5 mm; Package Quantity: 1; Package Reference: 402; Package Shape: Rectangular; Package Style: SMTMeter; Packaging: TapeandReel; Power Rating: 100mW; Pricing 1: 1=0.10000, 10=0.03300, 100=0.01330, 1000=0.00599, 2500=0.00520, 5000=0.00429 (USD); Radiation Hardening: No; Rated Power Dissipation (P): 100mW; REACH SVHC: NoSVHC; Resistor Type: FIXED RESISTOR; RoHS: Yes; RoHS Compliant: Yes; RoHSCompliant: True; Size Code: 0402; Stock 1: 108; Supplier 1: Digi-Key; Supplier Part Number 1: P10.0KLDKR-ND; Technology: METAL GLAZE/THICK FILM; Terminal Finish: Nickel; Termination: SMD/SMT; Tolerance: 1%; Voltage Rating (DC): 50V; Width: 0.02inch; Working Voltage: 50V
R11 = CMP-2005-03789-1: Manufacturer: Panasonic; Manufacturer Part Number: ERJ14BQF1R0U; Rated Power Dissipation (P): 500mW; Rated Temperature: 70°C; Reference Standard: AEC-Q200; Temperature Coefficient: 100ppm/°C; Tolerance: 1%; Value: 1 Ohm; Category: Chip SMD Resistors; Component Kind: Standard; ComponentLink1Description: https://datasheet.ciiva.com:443/pdfs/VipMasterIC/IC/PANA/PANA-S-A0009615198/PANA-S-A0009615198-1.pdf?src-supplier=IHS+Markit; ComponentLink1URL: https://datasheet.ciiva.com:443/pdfs/VipMasterIC/IC/PANA/PANA-S-A0009615198/PANA-S-A0009615198-1.pdf?src-supplier=IHS+Markit; ComponentLink2Description: https://datasheet.ciiva.com/11810/0900766b80eefa12-11810873.pdf?src-supplier=RSComponents; ComponentLink2URL: https://datasheet.ciiva.com/11810/0900766b80eefa12-11810873.pdf?src-supplier=RSComponents; ComponentLink3Description: https://datasheet.ciiva.com/48533/erj-eng-tds-48533543.pdf?src-supplier=Distrelec; ComponentLink3URL: https://datasheet.ciiva.com/48533/erj-eng-tds-48533543.pdf?src-supplier=Distrelec; ComponentLink4Description: https://datasheet.ciiva.com/48516/erj-eng-tds-48516877.pdf?src-supplier=Distrelec; ComponentLink4URL: https://datasheet.ciiva.com/48516/erj-eng-tds-48516877.pdf?src-supplier=Distrelec; ComponentLink5Description: https://datasheet.ciiva.com/24055/aoa0000ce3-72189-24055482.pdf?src-supplier=Mouser; ComponentLink5URL: https://datasheet.ciiva.com/24055/aoa0000ce3-72189-24055482.pdf?src-supplier=Mouser; ComponentLink6Description: https://datasheet.ciiva.com/9198/aoa0000ce3-9198936.pdf?src-supplier=Avnet; ComponentLink6URL: https://datasheet.ciiva.com/9198/aoa0000ce3-9198936.pdf?src-supplier=Avnet; JESD-609 Code: e3; Library Name: Altium Content Vault; Max Operating Temperature: 125°C; Min Operating Temperature: -55°C; Mount: Surface Mount; Number of Pins: 2; Package Shape: Rectangular; Packaging: Tape and Reel; Part Number: ERJ14BQF1R0U; Pricing 1: 1=0.59000, 10=0.45200, 100=0.19010, 1000=0.10486, 2500=0.09831 (USD); Reach SVHC Compliant: Yes; Resistor Type: FIXED RESISTOR; RoHS: Yes; RoHS Compliant: Yes; Size Code: 1210; Stock 1: 77; Supplier 1: Digi-Key; Supplier Part Number 1: P17253CT-ND; Technology: METAL GLAZE/THICK FILM; Terminal Finish: Nickel; Termination: Wraparound
R12 R13 R15 R17-R26 = CMP-1011-00001-2: Manufacturer: Yageo / Phycomp; Manufacturer Part Number: RC0402JR-070RL; Package Height: 0.35mm; Package Length: 1mm; Package Width: 0.5mm; Rated Power Dissipation (P): 62.5mW; Rated Temperature: 70°C; Category: Chip SMD Resistors; Component Kind: Standard; ComponentLink1Description: https://datasheet.ciiva.com:443/pdfs/VipMasterIC/IC/YAGO/YAGO-S-A0008145938/YAGO-S-A0008145938-1.pdf?src-supplier=IHS+Markit; ComponentLink1URL: https://datasheet.ciiva.com:443/pdfs/VipMasterIC/IC/YAGO/YAGO-S-A0008145938/YAGO-S-A0008145938-1.pdf?src-supplier=IHS+Markit; ComponentLink2Description: https://datasheet.ciiva.com/2452/pyu-rc0402-51-rohs-l-6-2452608.pdf?src-supplier=Digi-Key; ComponentLink2URL: https://datasheet.ciiva.com/2452/pyu-rc0402-51-rohs-l-6-2452608.pdf?src-supplier=Digi-Key; ComponentLink3Description: https://datasheet.ciiva.com/11808/0900766b80c66297-11808195.pdf?src-supplier=RSComponents; ComponentLink3URL: https://datasheet.ciiva.com/11808/0900766b80c66297-11808195.pdf?src-supplier=RSComponents; ComponentLink4Description: https://datasheet.ciiva.com/7983/1018594-7983535.pdf?src-supplier=Element14; ComponentLink4URL: https://datasheet.ciiva.com/7983/1018594-7983535.pdf?src-supplier=Element14; ComponentLink5Description: https://datasheet.ciiva.com/22747/1018594-22747515.pdf?src-supplier=Element14; ComponentLink5URL: https://datasheet.ciiva.com/22747/1018594-22747515.pdf?src-supplier=Element14; ComponentLink6Description: https://datasheet.ciiva.com/2452/pyu-r-int-thick-7-2452609.pdf?src-supplier=Digi-Key; ComponentLink6URL: https://datasheet.ciiva.com/2452/pyu-r-int-thick-7-2452609.pdf?src-supplier=Digi-Key; ComponentLink7Description: https://datasheet.ciiva.com/2452/pyu-r-int-thick-7-2452610.pdf?src-supplier=Digi-Key; ComponentLink7URL: https://datasheet.ciiva.com/2452/pyu-r-int-thick-7-2452610.pdf?src-supplier=Digi-Key; ComponentLink8Description: https://datasheet.ciiva.com/8896/pyu-rc0402-51-rohs-l-6-8896201.pdf?src-supplier=Avnet; ComponentLink8URL: https://datasheet.ciiva.com/8896/pyu-rc0402-51-rohs-l-6-8896201.pdf?src-supplier=Avnet; ComponentLink9Description: https://datasheet.ciiva.com/15189/getdatasheetpartid-326379-15189533.pdf?src-supplier=Verical; ComponentLink9URL: https://datasheet.ciiva.com/15189/getdatasheetpartid-326379-15189533.pdf?src-supplier=Verical; Construction: Chip; JESD-609 Code: e3; Library Name: Altium Content Vault; Max Operating Temperature: 155°C; Min Operating Temperature: -55°C; Mount: Surface Mount; Number of Pins: 2; Package Shape: Rectangular; Package Style: SMTMeter; Packaging: Tape and Reel; Part Number: RC0402JR-070RL; Pricing 1: 1=0.10000, 10=0.01200, 25=0.00840, 50=0.00640, 100=0.00480, 250=0.00364, 500=0.00290, 1000=0.00214, 5000=0.00154 (USD); REACH SVHC: Yes; Resistor Type: FIXED RESISTOR; RoHS: Yes; RoHS Compliant: Yes; Size Code: 0402; Stock 1: 41330354; Supplier 1: Digi-Key; Supplier Part Number 1: 311-0.0JRDKR-ND; Technology: METAL GLAZE/THICK FILM; Terminal Finish: Nickel; Termination: Wraparound; Value: 0R
R14 = CMP-2003-00017-1: Manufacturer: Panasonic; Manufacturer Part Number: ERJ-8ENF1001V; Package Height: 0.6mm; Package Length: 3.2mm; Package Width: 1.6mm; Rated Power Dissipation (P): 250mW; Rated Temperature: 70°C; Reference Standard: AEC-Q200; Temperature Coefficient: 100ppm/°C; Tolerance: 1%; Value: 1 kOhm; Working Voltage: 200V; Category: Chip SMD Resistors; Component Kind: Standard; ComponentLink1Description: https://datasheet.ciiva.com:443/pdfs/VipMasterIC/IC/PANA/PANA-S-A0009889626/PANA-S-A0009889626-1.pdf?src-supplier=IHS+Markit; ComponentLink1URL: https://datasheet.ciiva.com:443/pdfs/VipMasterIC/IC/PANA/PANA-S-A0009889626/PANA-S-A0009889626-1.pdf?src-supplier=IHS+Markit; Construction: Rectangular; JESD-609 Code: e3; Library Name: Altium Content Vault; Max Operating Temperature: 155°C; Min Operating Temperature: -55°C; Mount: Surface Mount; Number of Pins: 2; Package Shape: Rectangular; Package Style: SMTMeter; Packaging: Tape and Reel; Part Number: ERJ-8ENF1001V; Pricing 1: 1=0.18000, 10=0.15400, 100=0.06030, 1000=0.02522, 2500=0.02312 (USD); Reach SVHC Compliant: Yes; Resistor Type: FIXED RESISTOR; RoHS: Yes; RoHS Compliant: Yes; Size Code: 1206; Stock 1: 194943; Supplier 1: Digi-Key; Supplier Part Number 1: P1.00KFDKR-ND; Technology: METAL GLAZE/THICK FILM; Terminal Finish: Nickel; Termination: Wraparound
TP5-TP34 = testPoint_1mm: testPoint 1mm; Library Name: STB_Schlib.SchLib
X1 X7 = CMP-1502-00010-1: Male Box Header WR-BHD, THT, Vertical, pitch 2.54 mm, 20 pins; Manufacturer: Wurth Elektronik; Connector Type: Male Pin; Contact Resistance: 20mOhm; Current Rating: 3A; Insulation: Insulated; Insulation Resistance: 1GR; Number of Contacts: 20; Pitch: 2.54mm; Row Spacing: 0.1inch; Voltage Rating: 250V; Withstanding Voltage: 500VAC; Working Voltage: 250VAC; Category: Headers and Wire Housings; Color: Black; Component Kind: Standard; ComponentLink1Description: https://datasheet.ciiva.com/731/6120xx21621-731346.pdf?src-supplier=Digi-Key; ComponentLink1URL: https://datasheet.ciiva.com/731/6120xx21621-731346.pdf?src-supplier=Digi-Key; Contact Gender: Male; Contact Material: Copper Alloy,Copper; Contact Plating: Gold; Contact Type: Male Pin; Datasheet URL: https://katalog.we-online.de/em/datasheet/6120xx21621.pdf; Flammability Rating: UL94 V-0; Gender: Male; Height: 8.85mm; Housing Material: PBT; Length: 33.06mm; Library Name: Altium Content Vault; Manufacturer Part Number: 61202021621; Manufacturer URL: http://www.we-online.de; Max Operating Temperature: 125°C; Min Operating Temperature: -40°C; Mount: Through Hole; Mounting Technology: THT; Number of Positions: 20; Number of Rows: 2; Operating Temperature: -40to125degC; Orientation: Vertical; Package Quantity: 160; Package Reference: 61202021621; Packaging: Tray; Part Number: 61202021621; Pin Number: 20; Pricing 1: 1=0,95000, 10=0,93200, 50=0,85900, 160=0,76900, 320=0,68000 (USD); Published Date: August2018; REACH SVHC: Unknown; RoHS: Yes; RoHS Compliant: Yes; Series: WR-BHD; Stock 1: 19791; Supplier 1: Digi-Key; Supplier Part Number 1: 732-2096-ND; Termination: Through Hole; Width: 33.06mm; Publisher: WurthElektronik
X2-X4 X6 = CMP-1559-01627-1: OMNIMATE Signal - series PM; Manufacturer: Weidmueller; Additional Feature: STANDARD: UL 94V-0; Contact_material: Cu-Leg; Contact_surface: tinned; Current Rating: 24A; Fastening Method: SOLDER; Insulating_material: Wemid (PA); Mounting_onto_the_PCB: Solder connection; No_of_poles: 2; Number of Decks: 1; Pitch_inch: 0,2 inch; Pitch_mm: 5,08 mm; Rated_current_use_group_B_UL_1059: 15 A; Rated_voltage_for_surge_voltage_class_pollution_degree_II_2: 600 V; Rated_voltage_use_group_B_UL_1059: 300 V; Safety Approval: UL,CSA; Short_text_for_material: PM 5.08/02/90 3.5SN OR BX; Side_termination_characteristic: closed side; Solder_pin_length_mm: 3,5 mm; Solid_max_H05_07_V_U: 2,5 mm²; Solid_min_H05_07_V_U: 0,13 mm²; UL_94_flammability_rating: V-0; Voltage Rating: 600V; Wire Cross Section: 2.5mm2; Wire/Cable Gauge: 14AWG; Wire_cross_section_AWG_max_UL_1059: AWG 14; Wire_cross_section_AWG_min_UL_1059: AWG 26; Category: Terminal Blocks; Colour: Orange; Component Kind: Standard; ComponentLink1Description: Manufacturer URL; ComponentLink1URL: http://www.weidmueller.com/; ComponentLink2Description: URL Link1; ComponentLink2URL: http://catalog.weidmueller.com/catalog/Start.do?localeId=en&ObjectID=1760490000; ComponentLink3Description: https://datasheet.ciiva.com:443/pdfs/VipMasterIC/IC/WEID/WEIDS07855/WEIDS07855-1.pdf?src-supplier=IHS+Markit; ComponentLink3URL: https://datasheet.ciiva.com:443/pdfs/VipMasterIC/IC/WEID/WEIDS07855/WEIDS07855-1.pdf?src-supplier=IHS+Markit; ComponentLink4Description: https://datasheet.ciiva.com/16046/1760490000-pm-5-08-02-90-3-5sn-or-bx-en-pdf-148-16046417.pdf?src-supplier=Onlinecomponents.com; ComponentLink4URL: https://datasheet.ciiva.com/16046/1760490000-pm-5-08-02-90-3-5sn-or-bx-en-pdf-148-16046417.pdf?src-supplier=Onlinecomponents.com; ComponentLink5Description: https://datasheet.ciiva.com/823/1760490000-823936.pdf?src-supplier=Digi-Key; ComponentLink5URL: https://datasheet.ciiva.com/823/1760490000-823936.pdf?src-supplier=Digi-Key; Library Name: Altium Content Vault; Manufacturer Part Number: 1760490000; Manufacturer Series: 1760490000; Mount: Board; Number of Rows: 1; Number of Ways: 2; PackageReference: PM_5_08_90_02; Packaging: Box; PartNumber: 1760490000; Pricing 1: 1=0.72000, 10=0.45000, 25=0.38480, 50=0.34600, 100=0.33870, 250=0.28828, 500=0.27386, 1000=0.24503, 5000=0.20820 (USD); Qty: 500 PCS; REACH SVHC: Yes; Revision: V1; RoHS: Yes; RoHS Compliant: Yes; Stock 1: 17520; Supplier 1: Digi-Key; Supplier Part Number 1: 281-2888-ND; Terminal and Terminal Block Type: MODULAR TERMINAL BLOCK
X5 X9 = CMP-2000-07502-1: Male Header, Pitch 2.54 mm, 2 x 5 Position, Height 9.855 mm, Tail Length 3.048 mm, -65 to 105 degC, RoHS, Tube; Manufacturer: TE Connectivity; Board Standoff: Yes(With); Body Material: Thermoplastic; Connector System: Wire-to-Board; Current Rating: 1A; Insulation Resistance: 5GR; Mating Connector Lock: No(Without); Number of Contacts: 10; PCB Mount Retention: Without; PCB Mounting Orientation: Vertical; Pitch: 2.54mm; Colour: Black; Component Kind: Standard; Connector Type: Header; Contact Gender: Male; Contact Material: CopperAlloy; Contact Mating Length: 0.24inch; Contact Plating: Gold; Contact Shape: Square; Datasheet URL: http://www.te.com/commerce/DocumentDelivery/DDEController?Action=showdoc&DocId=Customer+Drawing%7F5103309%7FE1%7Fpdf%7FEnglish%7FENG_CD_5103309_E1.pdf%7F5103309-1; Datasheet Version: Rev. E1, 03/2011; Depth: 9.14mm; ELV: Compliant; Flammability Rating: UL94V-0; Gender: Male; Height: 8.813mm; Housing Colour: Black; Housing Material: PBT; Lead Free: LeadFree; Length: 0.8inch; Library Name: Altium Content Vault; Manufacturer Part Number: 5103309-1; Manufacturer URL: http://www.te.com/; Mating Alignment: Yes(With); Mating Retention: No(Without); Max Current Rating: 1A; Max Operating Temperature: 105degC; Min Operating Temperature: -65degC; Mount: ThroughHole; Mounting Technology: ThroughHole; Number of Positions: 10; Number of Rows: 2; Orientation: Straight; Package Description: Male Header, Pitch 2.54 mm, 2 x 5 Position, Height 9.855 mm, Tail Length 3.048 mm; Package Quantity: 35; Packaging: Rail/Tube; Panel Mount Retention: No(Without); PCB Mount Alignment: No(Without); REACH SVHC: NoSVHC; RoHSCompliant: True; Row Spacing: 2.54mm; Shrouded: Yes(With); Shrouded End Dimension: 3.81mm; Termination: ThroughHole; Termination Post Length: 0.12inch
X8 = 2059-302/998-403: Barrier Strip Terminal Block, 3A, 0.34mm2, 1 Row(s), 1 Deck(s); Manufacturer: WAGO; Fastening Method: PUSH-IN; Number of Decks: 1; Number of Ways: 2; Safety Approval: UL; Voltage Rating: 250V; Wire/Cable Gauge: 22AWG; Category: Connectors; Component Kind: Standard; ComponentLink1Description: https://datasheet.ciiva.com:443/pdfs/VipMasterIC/IC/WAGO/WAGO-S-A0007107760/WAGO-S-A0007107948-1.pdf?src-supplier=IHS+Markit; ComponentLink1URL: https://datasheet.ciiva.com:443/pdfs/VipMasterIC/IC/WAGO/WAGO-S-A0007107760/WAGO-S-A0007107948-1.pdf?src-supplier=IHS+Markit; ComponentLink2Description: https://datasheet.ciiva.com/41441/001208172-da-01-de-smd-leiterplattenklemme-2059-302-998-404-41441808.pdf?src-supplier=Conrad; ComponentLink2URL: https://datasheet.ciiva.com/41441/001208172-da-01-de-smd-leiterplattenklemme-2059-302-998-404-41441808.pdf?src-supplier=Conrad; ComponentLink3Description: https://datasheet.ciiva.com/46435/1860449-46435420.pdf?src-supplier=Element14; ComponentLink3URL: https://datasheet.ciiva.com/46435/1860449-46435420.pdf?src-supplier=Element14; Current Rating: 3A; Manufacturer Part Number: 2059-302/998-403; Mount: Board; Number of Rows: 1; Pricing 1: 1=1.25000, 10=0.87800, 25=0.81880, 50=0.74320, 100=0.73990, 250=0.62484, 500=0.59194 (USD); REACH SVHC: Yes; RoHS: Yes; RoHS Compliant: Yes; Stock 1: 17368; Supplier 1: Digi-Key; Supplier Part Number 1: 2946-2059-302/998-403CT-ND; Terminal and Terminal Block Type: BARRIER STRIP TERMINAL BLOCK; Wire Cross Section: 0.34mm2; Library Name: STB_Schlib.SchLib
